# Altium schematic sheet ARDUSAT-EEMC.SchDoc(ARDUSAT-EEMC)
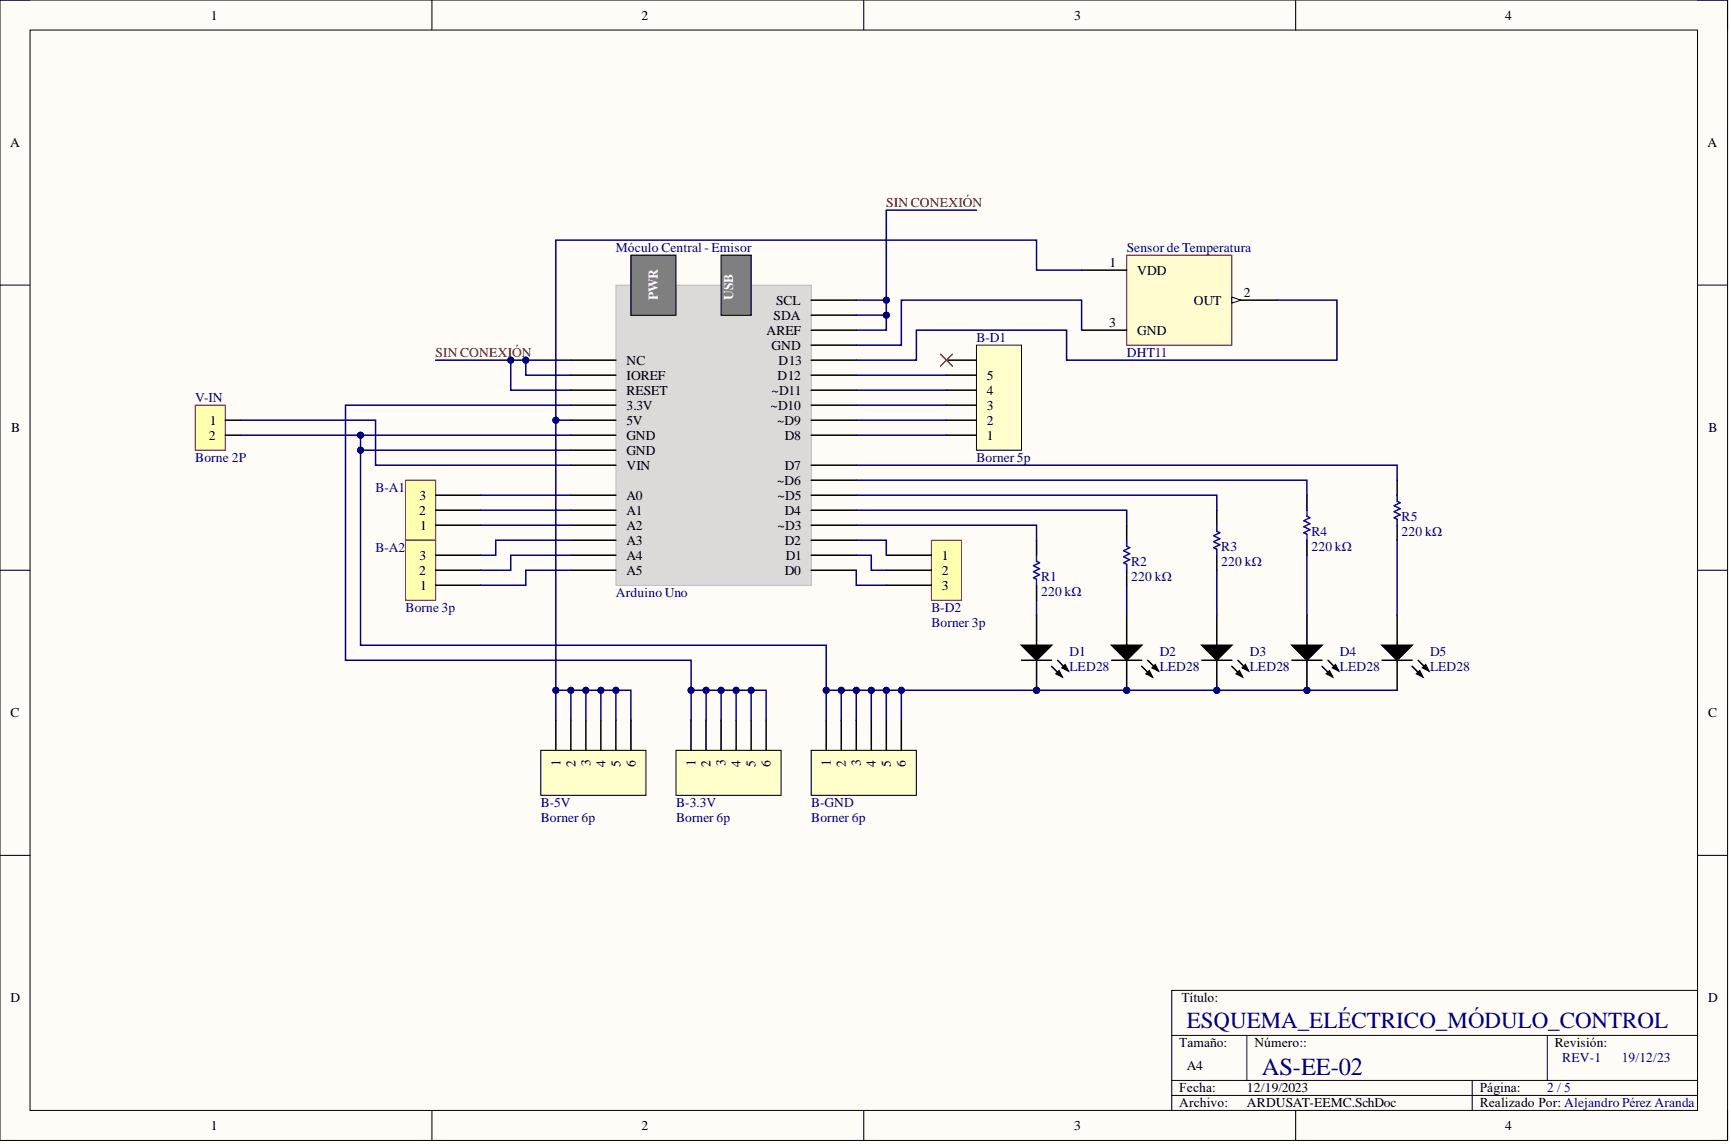
[diagram p0: ARDUSAT-EEMC.SchDoc]

## Parts
B-3.3V  Borner 6p  [PCB-1c3e8svsyb2q9vm7noum-2]
B-5V  Borner 6p  [PCB-1c3e8svsyb2q9vm7noum-2]
B-A1  Borne 3p  (value not drawn)
B-A2  Megaradar 5,08mm 3 POS  (value not drawn)
B-D1  Borner 5p  [PCB-1c3e8svsyb2q9vm7noum-2]
B-D2  Borner 3p
B-GND  Borner 6p  [PCB-1c3e8svsyb2q9vm7noum-2]
D1  LED28
D2  LED28
D3  LED28
D4  LED28
D5  LED28
Móculo_Central_-_Emisor  Arduino Uno
R1  R1  [PCB-q66ntzze7gytxksj4v9p-1]
R2  R2  Resistance: 220 kΩ  [PCB-q66ntzze7gytxksj4v9p-1]
R3  R3  Resistance: 220 kΩ  [PCB-q66ntzze7gytxksj4v9p-1]
R4  R4  Resistance: 220 kΩ  [PCB-q66ntzze7gytxksj4v9p-1]
R5  R5  Resistance: 220 kΩ  [PCB-q66ntzze7gytxksj4v9p-1]
Sensor_de_Temperatura  DHT11
V-IN  Borne 2P  [PCB-vroqkc842fw3q2mkets7-1]

## Nets
SIN CONEXIÓN: Móculo_Central_-_Emisor.AREF Móculo_Central_-_Emisor.IOREF Móculo_Central_-_Emisor.NC Móculo_Central_-_Emisor.RESET Móculo_Central_-_Emisor.SCL Móculo_Central_-_Emisor.SDA
NetB-3.3V_1: B-3.3V.1 B-3.3V.2 B-3.3V.3 B-3.3V.4 B-3.3V.5 B-3.3V.6 Móculo_Central_-_Emisor.3.3V
NetB-5V_1: B-5V.1 B-5V.2 B-5V.3 B-5V.4 B-5V.5 B-5V.6 Móculo_Central_-_Emisor.5V Sensor_de_Temperatura.1
NetB-A1_1: B-A1.1 Móculo_Central_-_Emisor.A2
NetB-A1_2: B-A1.2 Móculo_Central_-_Emisor.A1
NetB-A1_3: B-A1.3 Móculo_Central_-_Emisor.A0
NetB-A2_1: B-A2.1 Móculo_Central_-_Emisor.A5
NetB-A2_2: B-A2.2 Móculo_Central_-_Emisor.A4
NetB-A2_3: B-A2.3 Móculo_Central_-_Emisor.A3
NetB-D1_1: B-D1.1 Móculo_Central_-_Emisor.D8
NetB-D1_2: B-D1.2 Móculo_Central_-_Emisor.D9
NetB-D1_3: B-D1.3 Móculo_Central_-_Emisor.D10
NetB-D1_4: B-D1.4 Móculo_Central_-_Emisor.D11
NetB-D1_5: B-D1.5 Móculo_Central_-_Emisor.D12
NetB-D2_1: B-D2.1 Móculo_Central_-_Emisor.D2
NetB-D2_2: B-D2.2 Móculo_Central_-_Emisor.D1
NetB-D2_3: B-D2.3 Móculo_Central_-_Emisor.D0
NetB-GND_1: B-GND.1 B-GND.2 B-GND.3 B-GND.4 B-GND.5 B-GND.6 D1.2 D2.2 D3.2 D4.2 D5.2 Móculo_Central_-_Emisor.GND1 Móculo_Central_-_Emisor.GND2 V-IN.2
NetD1_1: D1.1 R1.1
NetD2_1: D2.1 R2.1
NetD3_1: D3.1 R3.1
NetD4_1: D4.1 R4.1
NetD5_1: D5.1 R5.1
NetMóculo Central - Emisor_D3: Móculo_Central_-_Emisor.D3 R1.2
NetMóculo Central - Emisor_D4: Móculo_Central_-_Emisor.D4 R2.2
NetMóculo Central - Emisor_D5: Móculo_Central_-_Emisor.D5 R3.2
NetMóculo Central - Emisor_D6: Móculo_Central_-_Emisor.D6 R4.2
NetMóculo Central - Emisor_D7: Móculo_Central_-_Emisor.D7 R5.2
NetMóculo Central - Emisor_D13: Móculo_Central_-_Emisor.D13 Sensor_de_Temperatura.2
NetMóculo Central - Emisor_GND3: Móculo_Central_-_Emisor.GND3 Sensor_de_Temperatura.3
NetMóculo Central - Emisor_VIN: Móculo_Central_-_Emisor.VIN V-IN.1

Not connected: B-D1 6

## Library data of the parts
B-3.3V B-5V B-D1 B-GND = CMP-e73e2c69529c38f9-13: SERVAL Born Negra8; Manufacturer Part Number: 282837-6; ELV Compliant: Compliant; Lead-Free Status: Lead Free; Number of Contacts: 8; Pitch: 5.08mm; Rated Current: 13.5A; Rated Voltage: 300V; Write Gauge: 16 to 28 AWG; Color: Green; Component Kind: Standard; Contact Material: Brass; Contact Plating: Tin; Flammability Rating: UL 94 V-0; Housing Color: Green; Housing Material: Nylon 6/6; Library Name: My Personal Space; Lifecycle Status: Active; Mounting Style: PC Board, PCB; Number of Positions: 8; Octopart URL: http://octopart.com/282837-6-te+connectivity-39686340; OctopartID: e73e2c69529c38f9; RoHS: Compliant
B-A1 B-A2 B-D2 = CMP-a844614a3e337783-5: 5,08mm 3POS Terminal; Manufacturer Part Number: 1716030000; Lead-Free Status: Lead Free; Number of Contacts: 3; Pin Pitch: 5.08 mm; Wire Gauge: 12.0 AWG to 14.0 AWG; Color: Black; Component Kind: Standard; Contact Material: Copper; Contact Plating: Tin; Flammability Rating: UL 94 V-0; Housing Color: Black; Housing Material: Nylon; Library Name: My Personal Space; Mounting Style: Through Hole, Screw, PCB; Number of Positions: 3; Number of Rows: 1; Octopart Url: https://octopart.com/1716030000-weidmuller-307985; OctopartID: a844614a3e337783; Packaging: Bulk; RoHS: Compliant; Size-Height: 13.8 mm; Size-Length: 15.2 mm; Size-Width: 10.0 mm
D1-D5 = CMP-e4882d82c7303b4f-8: LED; PCB; Green; 30mcd; 0.232In.; T-1-3/4; 150mA; 2.2V; 565nm; 60deg; GaP; Diffused; Component Kind: Standard; Library Name: My Personal Space
Móculo_Central_-_Emisor = CMP-1073962-112: Microcontroller board, Uno [Arduino] A000066 Microcontroller board, Uno 7640152110092; Core Architecture: AVR; Number of Bits: 8; Component Kind: Standard; Library Name: My Personal Space; Manufacturer Part Number: A000066; Octopart URL: http://octopart.com/a000066-arduino-21222240; OctopartID: aa24626d5c2275e3; REACH SVHC Compliance: No SVHC; RoHS: Compliant
R1 = CMP-d70a1ef5a19f08cd-14: R Standard RM 7,5 (eng); Resistance: 220 kΩ; Resistance Tolerance: ±1%; Component Kind: Standard; Library Name: My Personal Space; Mounting Style: Axial; OctopartID: d70a1ef5a19f08cd
R2-R5 = CMP-d70a1ef5a19f08cd-14: R Standard RM 7,5 (eng); Resistance Tolerance: ±1%; Component Kind: Standard; Library Name: My Personal Space; Mounting Style: Axial; OctopartID: d70a1ef5a19f08cd
Sensor_de_Temperatura = CMP-f2473ad10e112d80-6: DHT11 basic temperature-humidity sensor + extras; Component Kind: Standard; Library Name: My Personal Space
V-IN = CMP-0689cddeb769d94e-1: Terminal Block Header, 2, 300 V, 15 A, 5.08 mm, Through Hole Right Angle, Header; Manufacturer Part Number: 796638-2; Current Rating: 12.0 A; ELV Compliant: Compliant; Gender: Male; Lead-Free Status: Lead Free; Number of Contacts: 2; Color: Green; Component Kind: Standard; Contact Material: Phosphor Bronze; Contact Plating: Tin; Flammability Rating: UL 94 V-0; Housing Color: Green; Housing Material: Nylon 6/6; Library Name: My Personal Space; Lifecycle Status: Active; Mounting Style: Through Hole, Vertical; Number of Positions: 2; Octopart URL: http://octopart.com/796638-2-te+connectivity-39687302; OctopartID: 0689cddeb769d94e; Orientation: Right Angle; Packaging: Box; REACH SVHC Compliance: No SVHC; RoHS: Compliant
